# Altium schematic sheet 5.04.51805A02_RKCSI_LVDS_HDMI.SchDoc(5.04.51805A02_RKCSI_LVDS_HDMI)
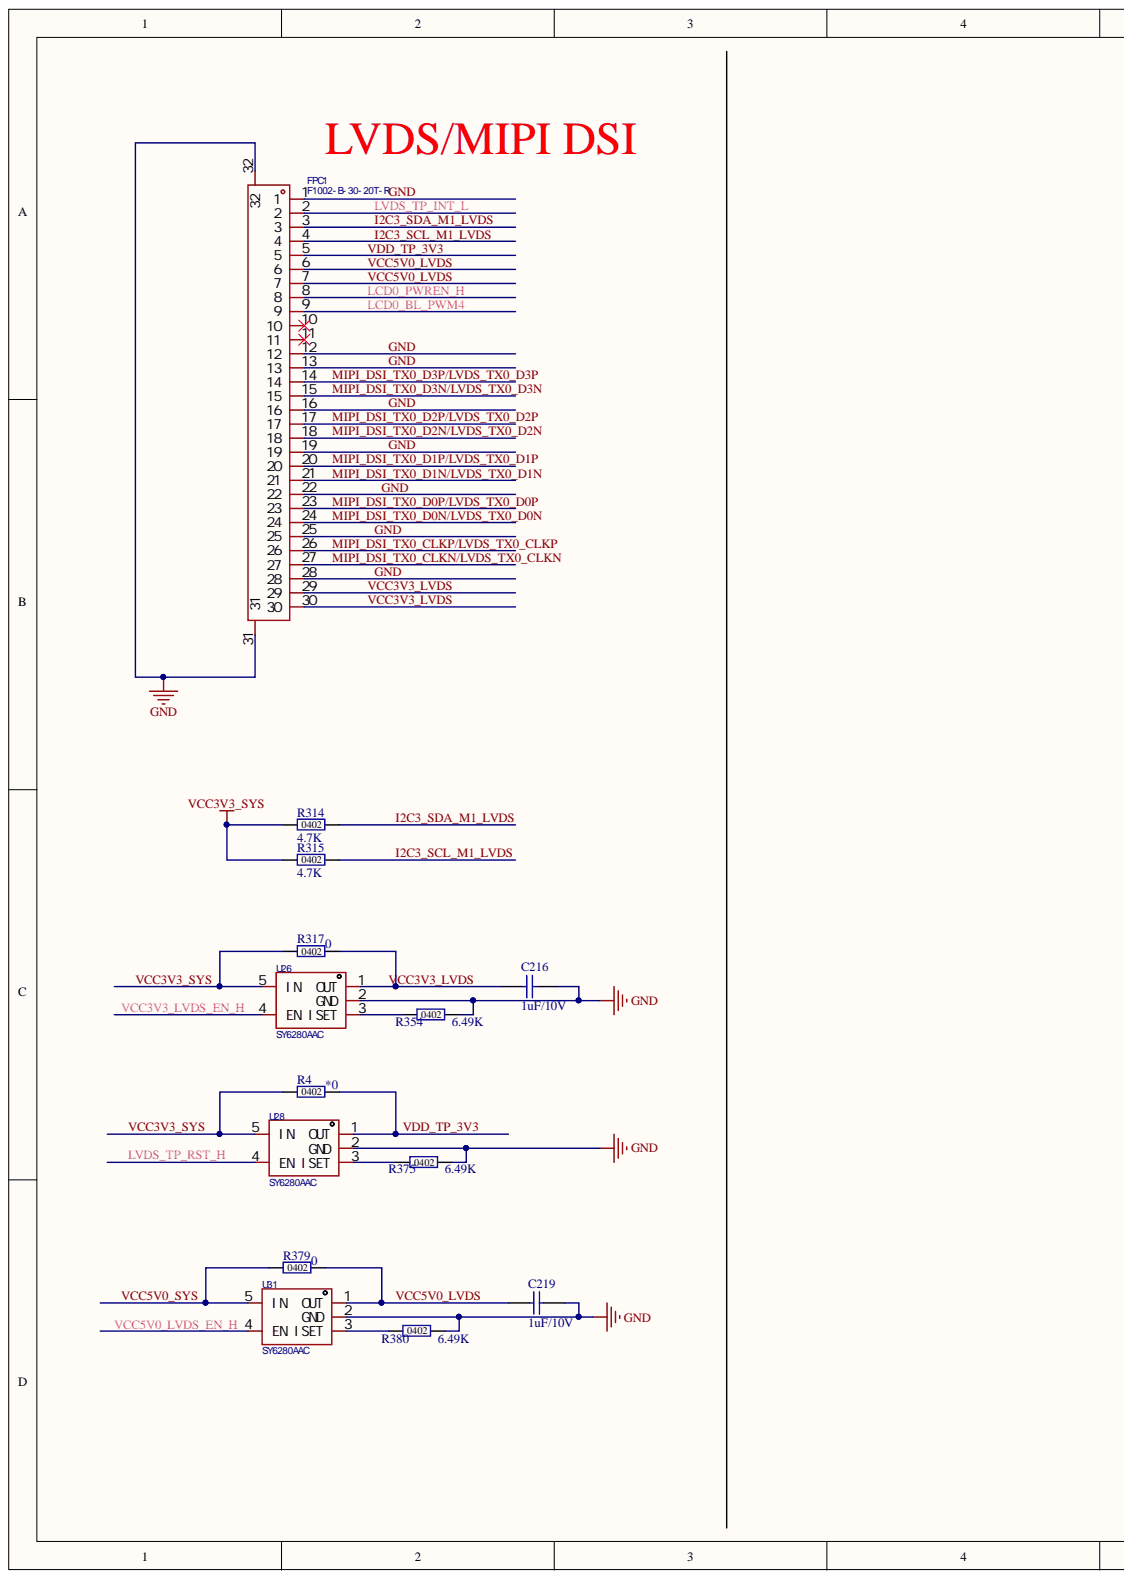
[diagram p0: 5.04.51805A02_RKCSI_LVDS_HDMI.SchDoc, left]
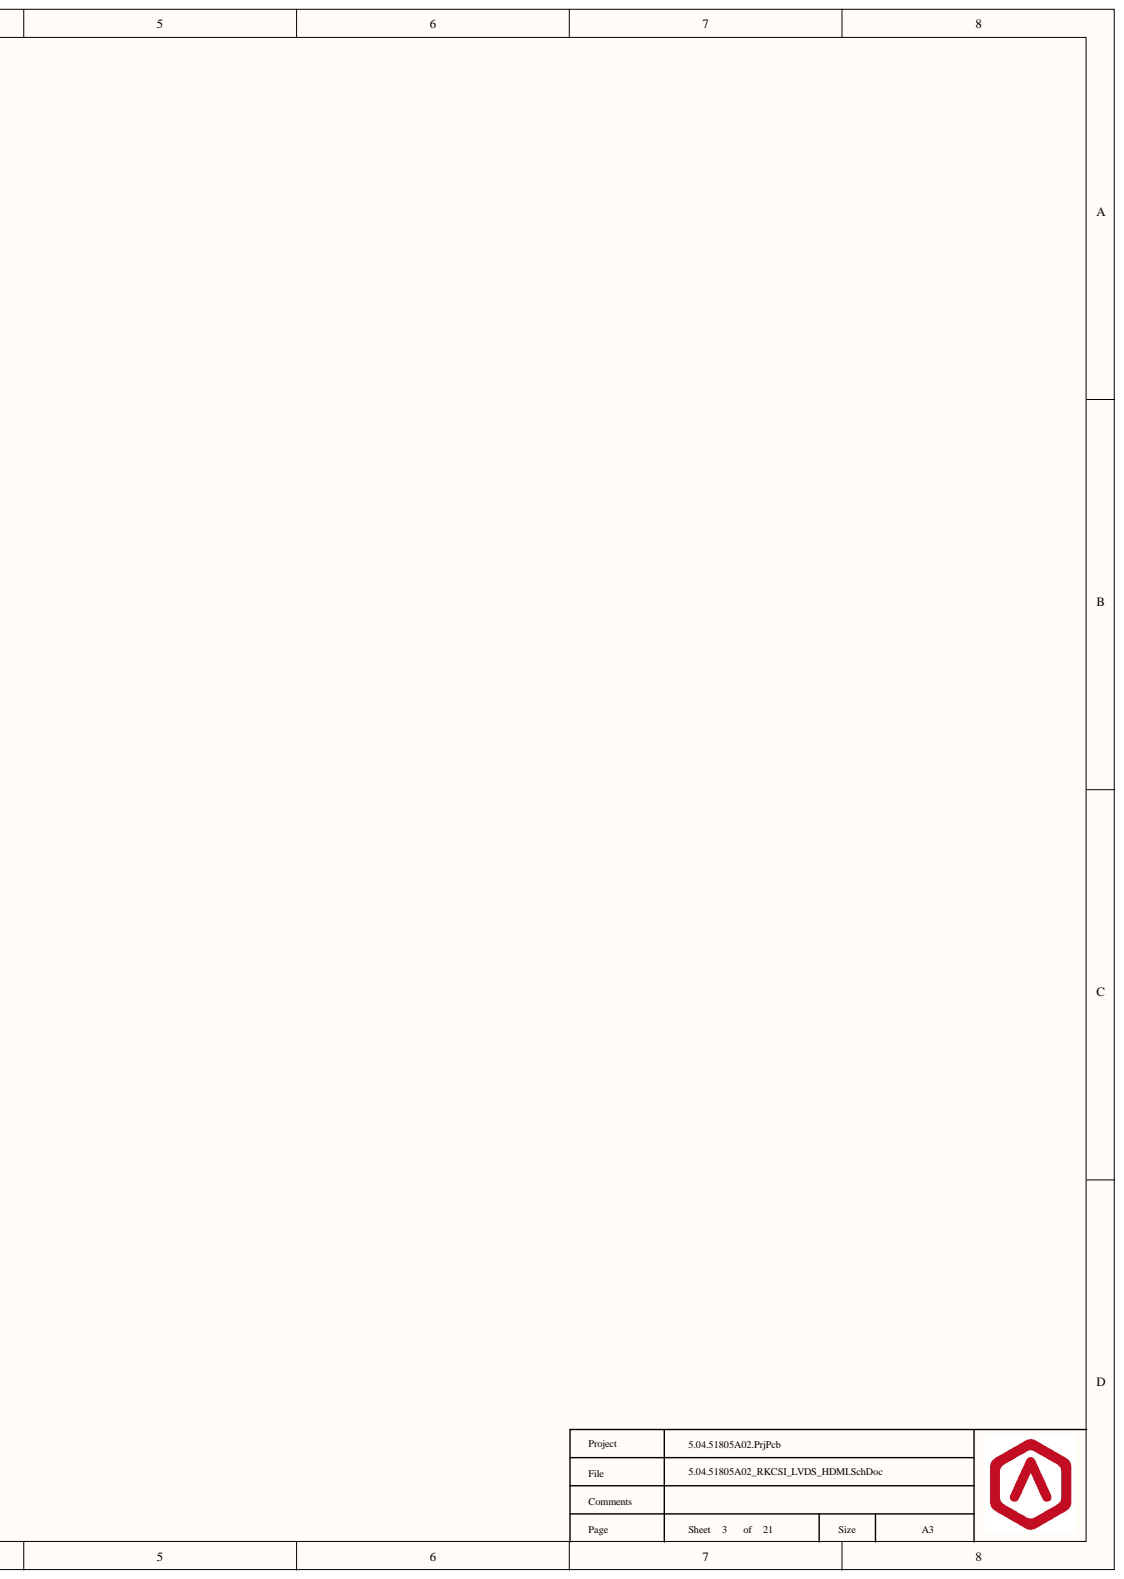
[diagram p1: 5.04.51805A02_RKCSI_LVDS_HDMI.SchDoc, right]

## Parts
C216  1uF/10V  [C0402]
C219  1uF/10V  [C0402]
FPC1  F1002-B-30-20T-R  [FFC-SMD_30P-P1.00_F1002-B-30-20T-R]
R4  *0  [R0402]
R314  4.7K  [R0402]
R315  4.7K  [R0402]
R317  0  [R0402]
R354  6.49K  [R0402]
R375  6.49K  [R0402]
R379  0  [R0402]
R380  6.49K  [R0402]
U26  SY6280AAC  [SOT-23-5_L3.0-W1.7-P0.95-LS2.8-BR]
U28  SY6280AAC  [SOT-23-5_L3.0-W1.7-P0.95-LS2.8-BR]
U31  SY6280AAC  [SOT-23-5_L3.0-W1.7-P0.95-LS2.8-BR]

## Nets
GND: C216.2 C219.2 FPC1.1 FPC1.12 FPC1.13 FPC1.16 FPC1.19 FPC1.22 FPC1.25 FPC1.28 FPC1.31 FPC1.32 R354.2 R375.2 R380.2 U26.2(GND) U28.2(GND) U31.2(GND)
I2C3_SCL_M1_LVDS: FPC1.4 R315.2
I2C3_SDA_M1_LVDS: FPC1.3 R314.2
LCD0_BL_PWM4: FPC1.9
LCD0_PWREN_H: FPC1.8
LVDS_TP_INT_L: FPC1.2
LVDS_TP_RST_H: U28.4(EN)
MIPI_DSI_TX0_CLKN/LVDS_TX0_CLKN: FPC1.27
MIPI_DSI_TX0_CLKP/LVDS_TX0_CLKP: FPC1.26
MIPI_DSI_TX0_D0N/LVDS_TX0_D0N: FPC1.24
MIPI_DSI_TX0_D0P/LVDS_TX0_D0P: FPC1.23
MIPI_DSI_TX0_D1N/LVDS_TX0_D1N: FPC1.21
MIPI_DSI_TX0_D1P/LVDS_TX0_D1P: FPC1.20
MIPI_DSI_TX0_D2N/LVDS_TX0_D2N: FPC1.18
MIPI_DSI_TX0_D2P/LVDS_TX0_D2P: FPC1.17
MIPI_DSI_TX0_D3N/LVDS_TX0_D3N: FPC1.15
MIPI_DSI_TX0_D3P/LVDS_TX0_D3P: FPC1.14
VCC3V3_LVDS: C216.1 FPC1.29 FPC1.30 R317.2 U26.1(OUT)
VCC3V3_LVDS_EN_H: U26.4(EN)
VCC3V3_SYS: R4.1 R314.1 R315.1 R317.1 U26.5(IN) U28.5(IN)
VCC5V0_LVDS: C219.1 FPC1.6 FPC1.7 R379.2 U31.1(OUT)
VCC5V0_LVDS_EN_H: U31.4(EN)
VCC5V0_SYS: R379.1 U31.5(IN)
VDD_TP_3V3: FPC1.5 R4.2 U28.1(OUT)
NetR354_1: R354.1 U26.3(ISET)
NetR375_1: R375.1 U28.3(ISET)
NetR380_1: R380.1 U31.3(ISET)

Not connected: FPC1 10 11

## Library data of the parts
C216 C219 = C0402_1uF_10V: Ceramic Capacitor, 1uF, ±10%, 10V, -55°C~125°C, C0402; Manufacturer1: Yageo; ManufacturerPartNumber1: CC0402KRX5R6BB105; Code: 5161C105; Component Kind: Standard; Library Name: Raise3D_Capacitor.IntLib
FPC1 = F1002-B-30-20T-R: 间距:1mm P数:30P 翻盖式 下接; Manufacturer1: XFCN(兴飞); Component Kind: Standard; ManufacturerPartNumber1: F1002-B-30-20T-R; Library Name: QQQ.IntLib
R4 R317 R379 = R0402_0: Resistor 0ohm,1%,1/16W,R0402; Manufacturer1: Yageo; ManufacturerPartNumber1: RC0402FR-070RL; Code: 5001000R; Component Kind: Standard; Library Name: Raise3D_Resistor.IntLib
R314 R315 = R0402_4.7K: Resistor 4.7Kohm,5%,1/16W,R0402; Manufacturer1: Yageo; ManufacturerPartNumber1: RC0402JR-074K7L; Code: 501104K7; Component Kind: Standard; Manufacturer2: Samsung; ManufacturerPartNumber2: RCS1005J472CS; Library Name: Raise3D_Resistor.IntLib
R354 R375 R380 = R0402_6.49K: Resistor 6.49Kohm,1%,1/16W,R0402; Manufacturer1: Samsung; ManufacturerPartNumber1: RC1005F6491CS; Code: 50016K49; Component Kind: Standard; Library Name: Raise3D_Resistor.IntLib
U26 U28 U31 = SY6280AAC: 供电开关; Manufacturer1: silergy(矽力杰); Component Kind: Standard; ManufacturerPartNumber1: SY6280AAC; Library Name: QQQ.IntLib
